# Altium schematic sheet buck4.schdoc
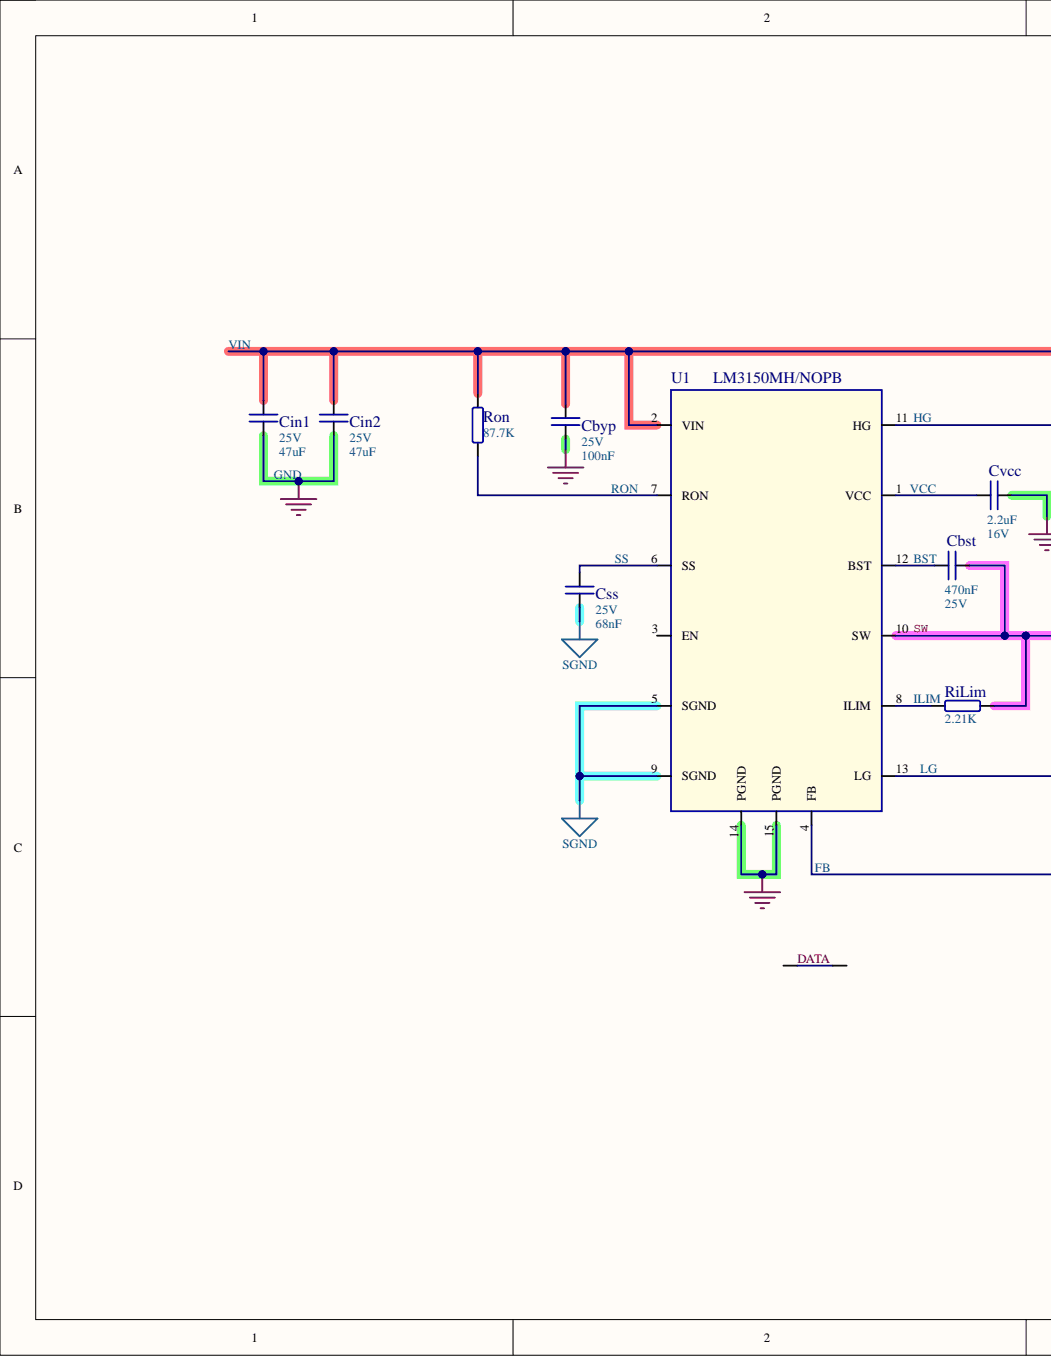
[diagram p0: buck4.schdoc, left]
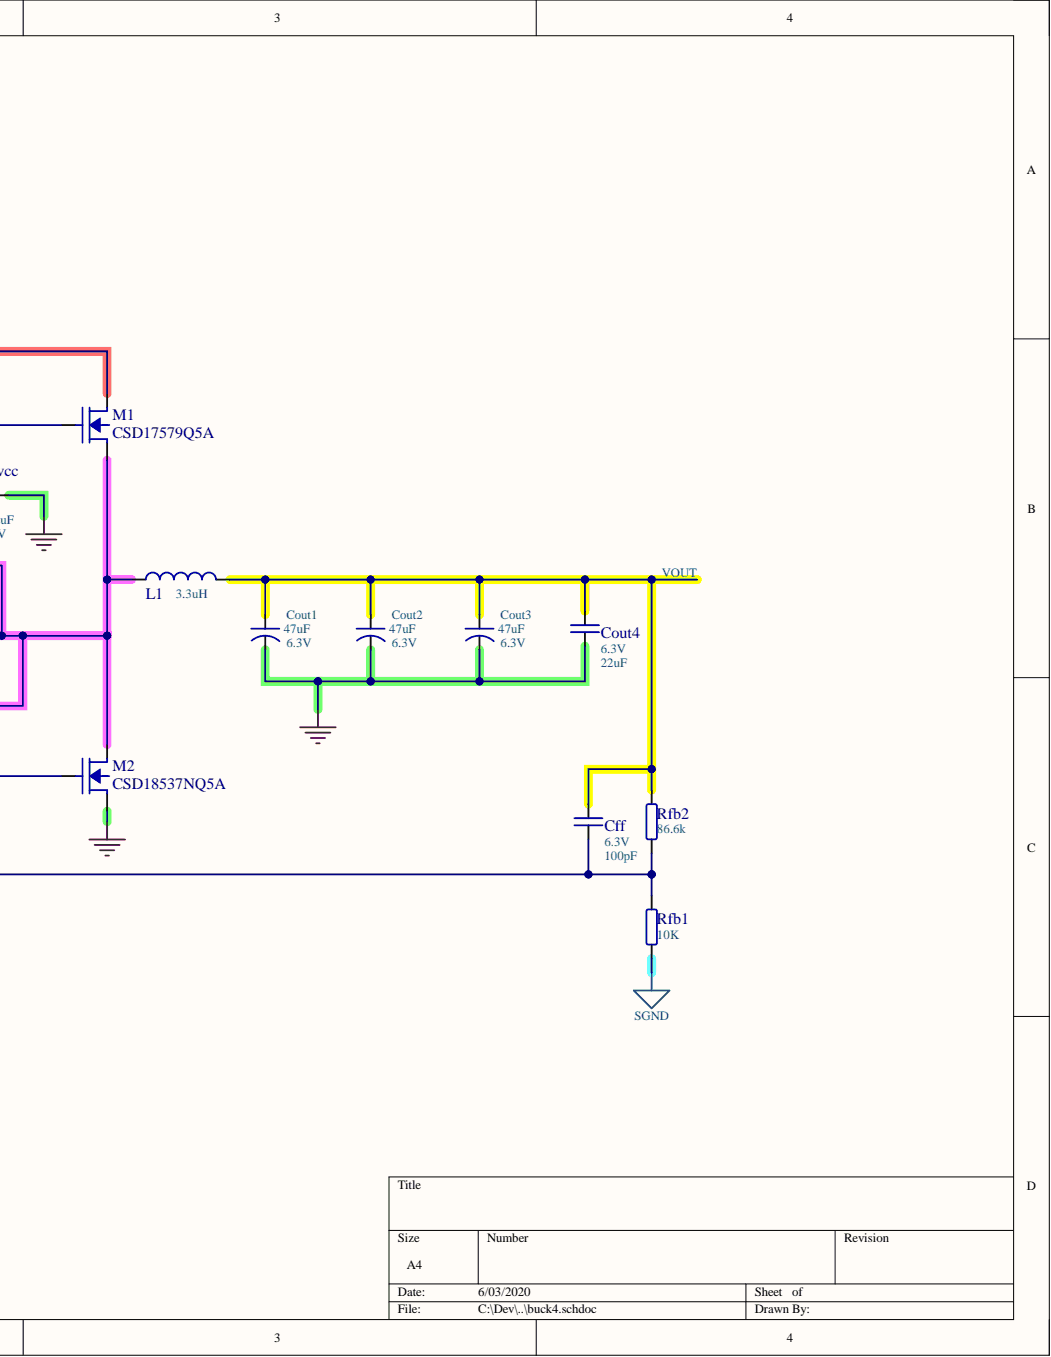
[diagram p1: buck4.schdoc, right]

## Parts
Cbst  value 470nF  Voltage: 25V  [CAPC2012X12L]  (hidden comment: MLCC)
Cbyp  value 100nF  Voltage: 25V  [CAPC2012X12L]  (hidden comment: MLCC)
Cff  value 100pF  Voltage: 6.3V  [CAPC1608X08L]  (hidden comment: MLCC)
Cin1  value 47uF  Voltage: 25V  [CAPC3216X12L]  (hidden comment: MLCC)
Cin2  value 47uF  Voltage: 25V  [CAPC3216X12L]  (hidden comment: MLCC)
Cout1  value 47uF  Voltage: 6.3V  [CAPMP7343X11L]  (hidden comment: CAPACITOR)
Cout2  value 47uF  Voltage: 6.3V  [CAPMP7343X11L]  (hidden comment: CAPACITOR)
Cout3  value 47uF  Voltage: 6.3V  [CAPMP7343X11L]  (hidden comment: CAPACITOR)
Cout4  value 22uF  Voltage: 6.3V  [CAPC3216X12L]  (hidden comment: MLCC)
Css  value 68nF  Voltage: 25V  [CAPC1608X08L]  (hidden comment: MLCC)
Cvcc  value 2.2uF  [CAPC1608X08L]  (hidden comment: MLCC)
DUMMY1  DATA_DUMMY  (value not drawn)  (no BOM)
DUMMY2  DATA_DUMMY  (value not drawn)  (no BOM)
L1  value 3.3uH  [WE-HCI_1335]  (hidden comment: 744313330)
M1  CSD17579Q5A  [TRANS_NexFET_Q5A]
M2  CSD18537NQ5A  [TRANS_NexFET_Q5A]
Rfb1  value 10K  [RESC1608X03L]  (hidden comment: RES0603)
Rfb2  value 86.6k  [RESC1608X03L]  (hidden comment: RES0603)
RiLim  value 2.21K  [RESC1608X03L]  (hidden comment: RES0603)
Ron  value 87.7K  [RESC1608X03L]  (hidden comment: RES0603)
U1  LM3150MH/NOPB  [MXA14A]

## Nets
SGND: Css.1 Rfb1.1 U1.5(SGND) U1.9(SGND)
BST: Cbst.1 U1.12(BST)
DATA: DUMMY1.3 DUMMY2.3
FB: Cff.1 Rfb1.2 Rfb2.1 U1.4(FB)
GND: Cbyp.1 Cin1.1 Cin2.1 Cout1.2 Cout2.2 Cout3.2 Cout4.1 Cvcc.2 M2.S U1.14(PGND) U1.15(PGND)
HG: M1.G U1.11(HG)
ILIM: RiLim.1 U1.8(ILIM)
LG: M2.G U1.13(LG)
RON: Ron.2 U1.7(RON)
SS: Css.2 U1.6(SS)
SW: Cbst.2 L1.2 M1.S M2.D RiLim.2 U1.10(SW)
VCC: Cvcc.1 U1.1(VCC)
VIN: Cbyp.2 Cin1.2 Cin2.2 M1.D Ron.1 U1.2(VIN)
VOUT: Cff.2 Cout1.1 Cout2.1 Cout3.1 Cout4.2 L1.1 Rfb2.2

Not connected: U1 3(EN)

## Library data of the parts
Cbst Cbyp Cff Cout4 Css = MLCC: Multilayer Ceramic Capacitor; Component Kind: Standard; Library Name: buck4.SchLib
Cin1 Cin2 = MLCC: Multilayer Ceramic Capacitor; MPN: C3216X5R1E476M160AC; Vendor: TDK; Component Kind: Standard; Library Name: buck4.SchLib
Cout1-Cout3 = CAPACITOR: Electrolytic Capacitor; MPN: EEFCS1A470R; Vendor: Pansonic; Component Kind: Standard; Library Name: buck4.SchLib; TYPE: Polymer
Cvcc = MLCC: Multilayer Ceramic Capacitor; Voltage: 16V; Component Kind: Standard; Library Name: buck4.SchLib
L1 = 744313330: Inductor; Vendor: Wurth Elektronik; Component Kind: Standard; Library Name: buck4.SchLib; Manufacturer_Part_Number: 744313330; MPN: 744313330; RefDes: RefDes; TYPE: TYPE
M1 = CSD17579Q5A: N Channel MOSFET; IdsMax: 14.0A; QgTyp: 5.4nC; Rdsonat10V: 0.0084Ohm; VdsMax: 30.0V; Vendor: Texas Instruments; Component Kind: Standard; Datasheet_URL: http://www.ti.com/lit/ds/symlink/CSD17579Q5A.pdf; Library Name: buck4.SchLib; Manufacturer_Part_Number: CSD17579Q5A; MPN: CSD17579Q5A; Quantity: 1; RefDes: RefDes; TYPE: TYPE
M2 = CSD18537NQ5A: N Channel MOSFET; IdsMax: 50.0; QgTyp: 14.0; Rdson45: 0.014559; VdsMax: 60.0; Vendor: Texas Instruments; Component Kind: Standard; Datasheet_URL: http://www.ti.com/lit/ds/symlink/csd18537nq5a.pdf; Library Name: buck4.SchLib; Manufacturer_Part_Number: CSD18537NQ5A; MPN: CSD18537NQ5A; Quantity: 1; RefDes: RefDes; TYPE: TYPE
Rfb1 Rfb2 RiLim Ron = RES0603: Chip Resistor; Component Kind: Standard; Library Name: buck4.SchLib
U1 = LM3150MH/NOPB: Buck controller; Vendor: Texas Instruments; Component Kind: Standard; Library Name: buck4.SchLib; Manufacturer_Part_Number: LM3150MH/NOPB; MPN: LM3150MH/NOPB; RefDes: RefDes; TYPE: TYPE
DUMMY1 DUMMY2 = DATA_DUMMY: Library Name: buck4.SchLib
